# Altium schematic sheet Page E_Micro_SD.SchDoc
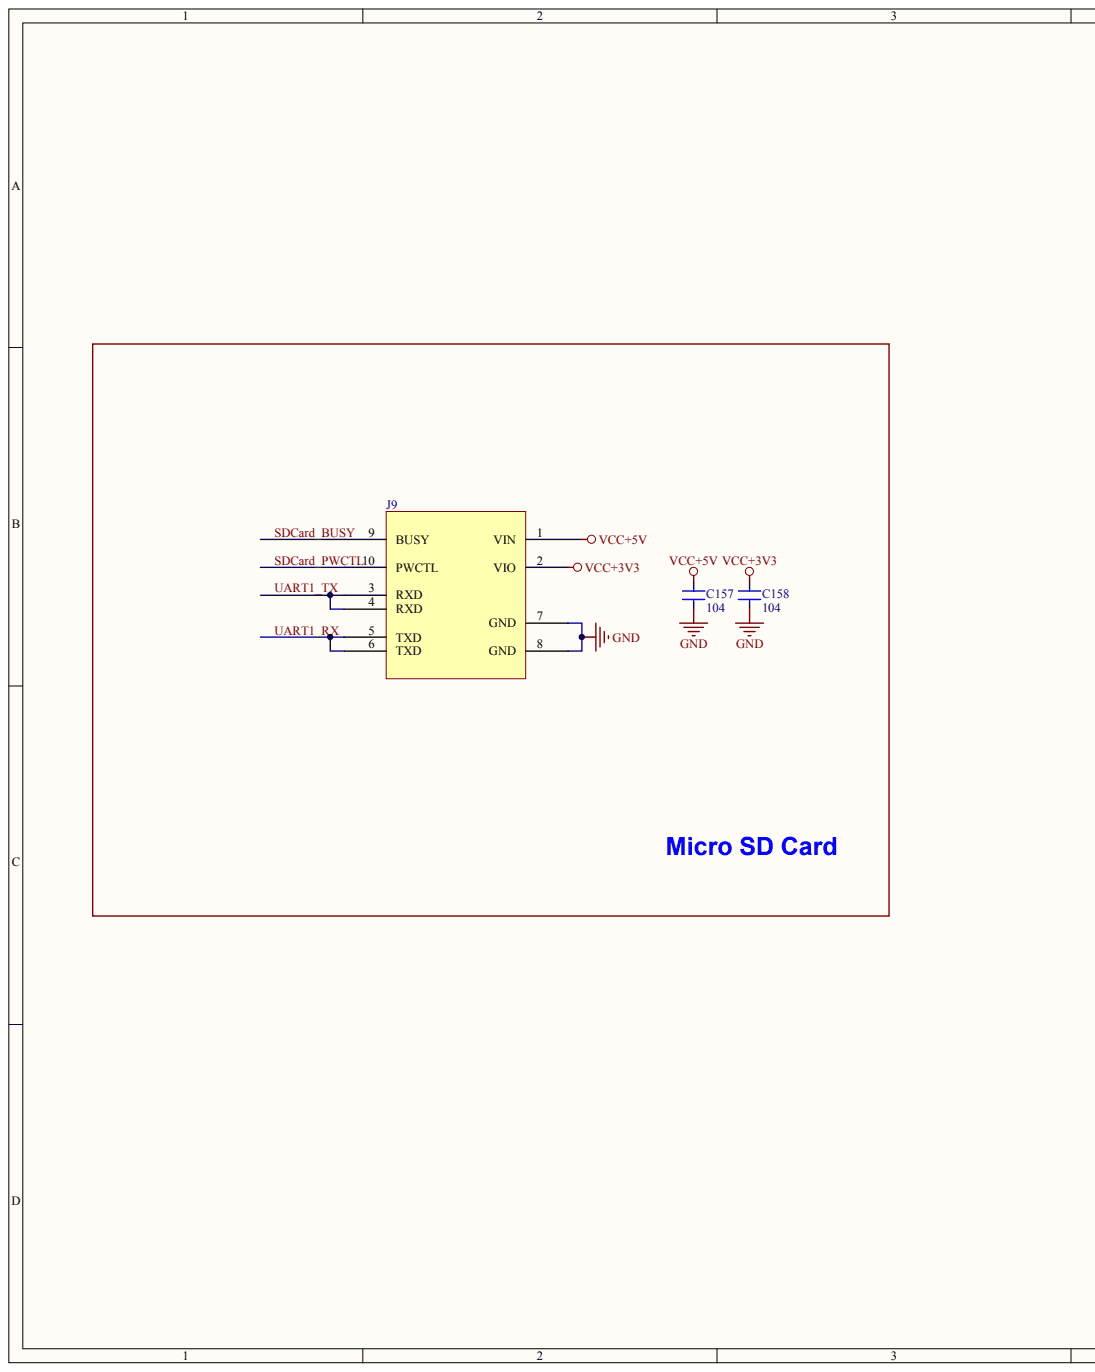
[diagram p0: Page E_Micro_SD.SchDoc, left]
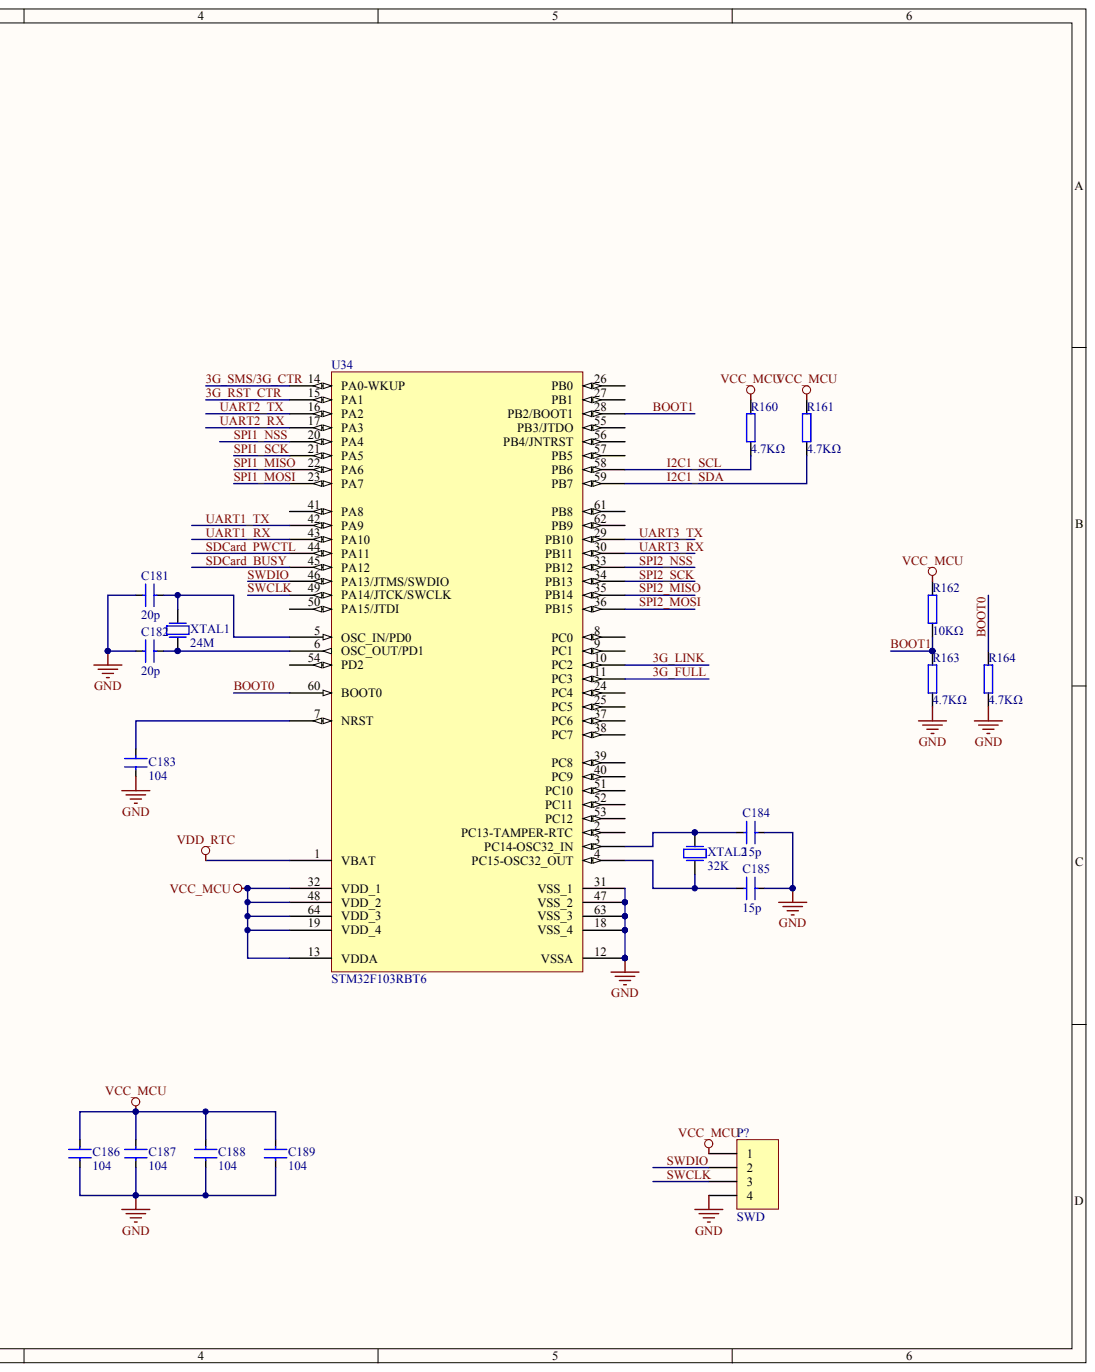
[diagram p1: Page E_Micro_SD.SchDoc, right]

## Parts
C157  104
C158  104
C181  20p
C182  20p
C183  104
C184  15p
C185  15p
C186  104
C187  104
C188  104
C189  104
J9  SDV600  (value not drawn)
P?  SWD
R160  4.7KΩ
R161  4.7KΩ
R162  10KΩ
R163  4.7KΩ
R164  4.7KΩ
U34  STM32F103RBT6
XTAL1  24M
XTAL2  32K

## Nets
GND: C157.1 C158.1 C181.2 C182.2 C183.1 C184.1 C185.1 C186.1 C187.1 C188.1 C189.1 J9.7(GND) J9.8(GND) P?.4 R163.2 R164.2 U34.12(VSSA) U34.18(VSS_4) U34.31(VSS_1) U34.47(VSS_2) U34.63(VSS_3)
VCC+3V3: C158.2 J9.2(VIO)
VCC+5V: C157.2 J9.1(VIN)
VCC_MCU: C186.2 C187.2 C188.2 C189.2 P?.1 R160.1 R161.1 R162.1 U34.13(VDDA) U34.19(VDD_4) U34.32(VDD_1) U34.48(VDD_2) U34.64(VDD_3)
VDD_RTC: U34.1(VBAT)
3G_FULL: U34.11(PC3)
3G_LINK: U34.10(PC2)
3G_RST_CTR: U34.15(PA1)
3G_SMS/3G_CTR: U34.14(PA0-WKUP)
BOOT0: R164.1 U34.60(BOOT0)
BOOT1: R162.2 R163.1 U34.28(PB2/BOOT1)
I2C1_SCL: R160.2 U34.58(PB6)
I2C1_SDA: R161.2 U34.59(PB7)
SDCARD_BUSY: J9.9(BUSY) U34.45(PA12)
SDCARD_PWCTL: J9.10(PWCTL) U34.44(PA11)
SPI1_MISO: U34.22(PA6)
SPI1_MOSI: U34.23(PA7)
SPI1_NSS: U34.20(PA4)
SPI1_SCK: U34.21(PA5)
SPI2_MISO: U34.35(PB14)
SPI2_MOSI: U34.36(PB15)
SPI2_NSS: U34.33(PB12)
SPI2_SCK: U34.34(PB13)
SWCLK: P?.3 U34.49(PA14/JTCK/SWCLK)
SWDIO: P?.2 U34.46(PA13/JTMS/SWDIO)
UART1_RX: J9.5(TXD) J9.6(TXD) U34.43(PA10)
UART1_TX: J9.3(RXD) J9.4(RXD) U34.42(PA9)
UART2_RX: U34.17(PA3)
UART2_TX: U34.16(PA2)
UART3_RX: U34.30(PB11)
UART3_TX: U34.29(PB10)
NetC181_1: C181.1 U34.5(OSC_IN/PD0) XTAL1.1
NetC182_1: C182.1 U34.6(OSC_OUT/PD1) XTAL1.2
NetC183_2: C183.2 U34.7(NRST)
NetC184_2: C184.2 U34.3(PC14-OSC32_IN) XTAL2.1
NetC185_2: C185.2 U34.4(PC15-OSC32_OUT) XTAL2.2

Not connected: U34 2(PC13-TAMPER-RTC) 8(PC0) 9(PC1) 24(PC4) 25(PC5) 26(PB0) 27(PB1) 37(PC6) 38(PC7) 39(PC8) 40(PC9) 41(PA8) 50(PA15/JTDI) 51(PC10) 52(PC11) 53(PC12) 54(PD2) 55(PB3/JTDO) 56(PB4/JNTRST) 57(PB5) 61(PB8) 62(PB9)

## Library data of the parts
R160-R164 = (no symbol name): Value: 1K
C157 C158 C181-C189 J9 P? U34 XTAL1 XTAL2 = (no symbol name)
  P?: LatestRevisionDate: 17-Jul-2002; LatestRevisionNote: Re-released for DXP Platform.
  U34: Published: 30-May-2008; DatasheetVersion: rev6, Mar-2008; PackageVersion: Mar-2008; PackageReference: LQFP64; PackageDescription: 64-Pin Low-Profile Quad Flat Pack, 10 x 10 mm Body, 0.5 mm Pitch (LQFP64); ComponentLink1Description: Manufacturer Link; ComponentLink1URL: www.st.com/stm32; ComponentLink2Description: Datasheet; ComponentLink2URL: http://www.st.com/stonline/products/literature/ds/13587.pdf
  P? U34: Publisher: Altium Limited
